# Altium schematic sheet Z24 - Arduino Mega Adapter.SchDoc("Z24 - Arduino Mega Adapter")
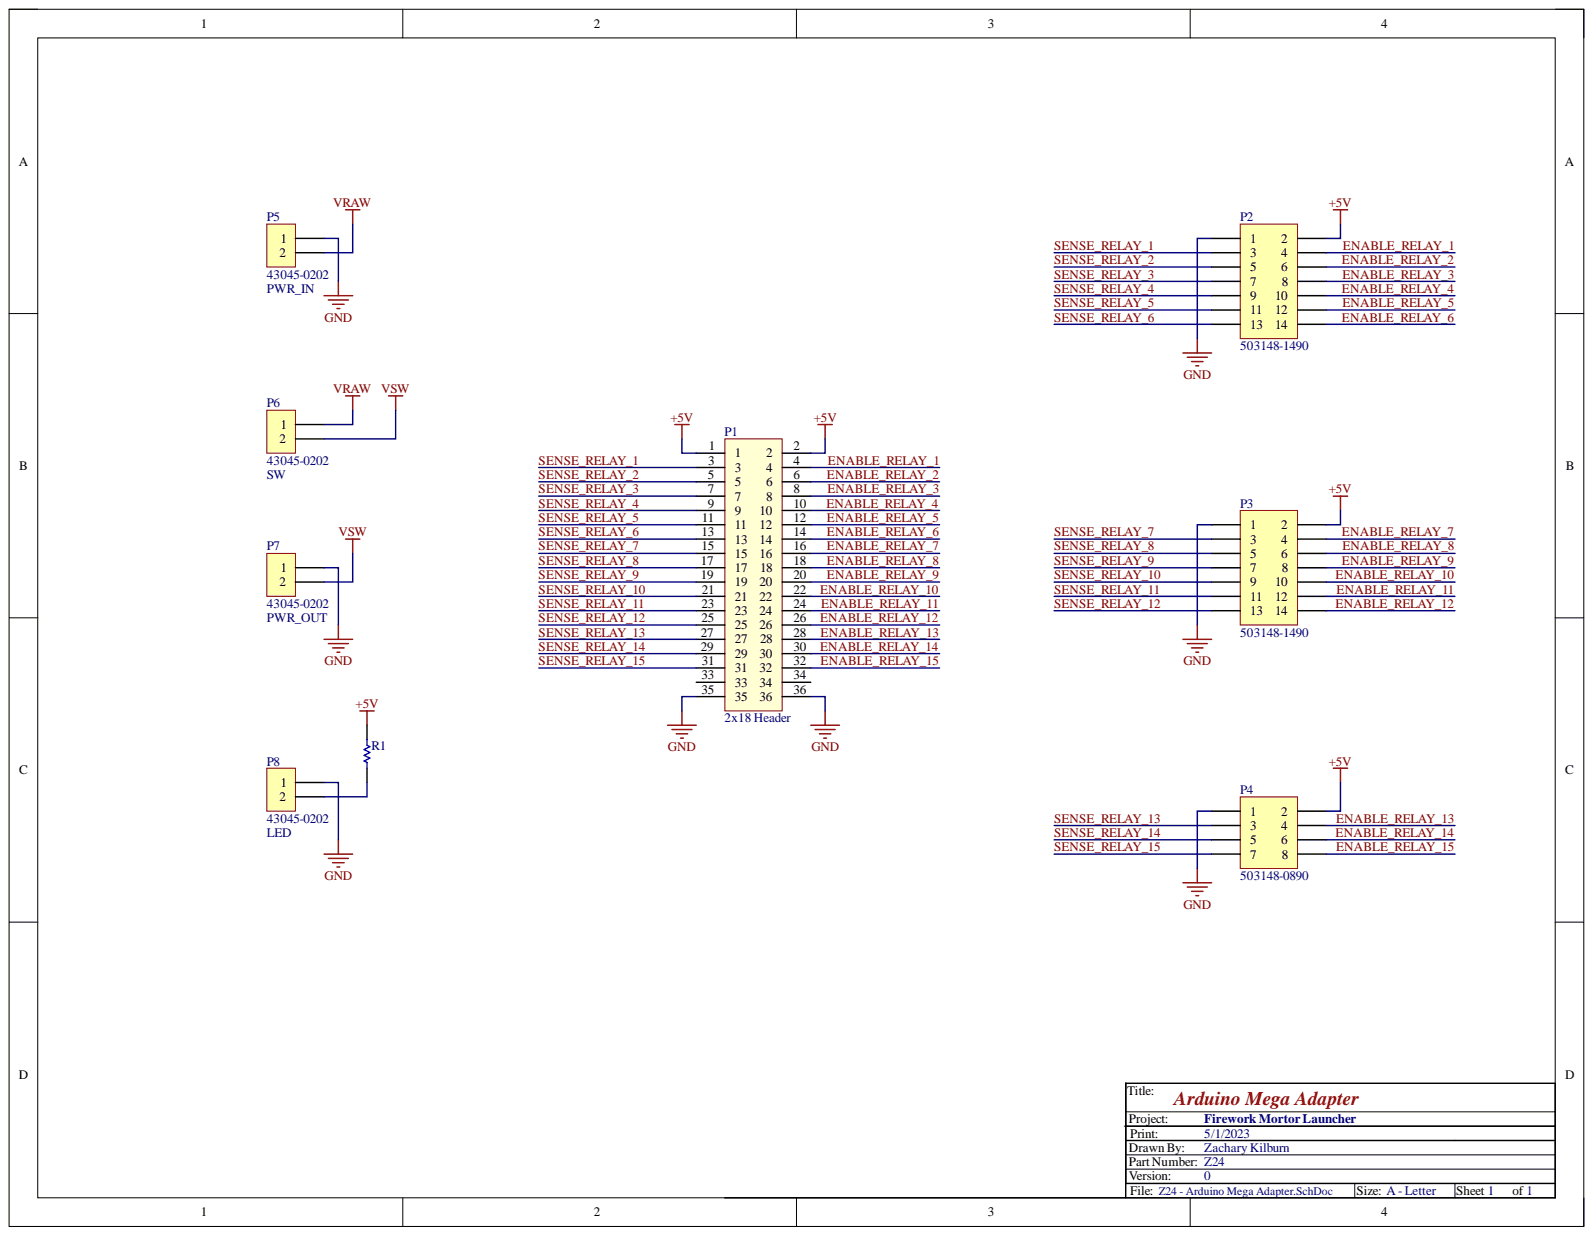
[diagram p0: Z24 - Arduino Mega Adapter.SchDoc]

## Parts
P1  2x18 Header  [2x18 PIN 0.1"]
P2  503148-1490  [PCC-MOLX-503148-1490-R]
P3  503148-1490  [PCC-MOLX-503148-1490-R]
P4  503148-0890  [PCC-MOLX-503148-0890-R]
P5  43045-0202  Name: PWR_IN  [PCC-MOLX-43045-0202-R]
P6  43045-0202  Name: SW  [PCC-MOLX-43045-0202-R]
P7  43045-0202  Name: PWR_OUT  [PCC-MOLX-43045-0202-R]
P8  43045-0202  Name: LED  [PCC-MOLX-43045-0202-R]
R1  ERA3AEB104V  [RESC1608X55X30ML20T20]  (value not drawn)

## Nets
+5V: P1.1 P1.2 P2.2 P3.2 P4.2 R1.2
GND: P1.35 P1.36 P2.1 P3.1 P4.1 P5.1 P7.1 P8.1
VRAW: P5.2 P6.1
VSW: P6.2 P7.2
ENABLE_RELAY_1: P1.4 P2.4
ENABLE_RELAY_2: P1.6 P2.6
ENABLE_RELAY_3: P1.8 P2.8
ENABLE_RELAY_4: P1.10 P2.10
ENABLE_RELAY_5: P1.12 P2.12
ENABLE_RELAY_6: P1.14 P2.14
ENABLE_RELAY_7: P1.16 P3.4
ENABLE_RELAY_8: P1.18 P3.6
ENABLE_RELAY_9: P1.20 P3.8
ENABLE_RELAY_10: P1.22 P3.10
ENABLE_RELAY_11: P1.24 P3.12
ENABLE_RELAY_12: P1.26 P3.14
ENABLE_RELAY_13: P1.28 P4.4
ENABLE_RELAY_14: P1.30 P4.6
ENABLE_RELAY_15: P1.32 P4.8
SENSE_RELAY_1: P1.3 P2.3
SENSE_RELAY_2: P1.5 P2.5
SENSE_RELAY_3: P1.7 P2.7
SENSE_RELAY_4: P1.9 P2.9
SENSE_RELAY_5: P1.11 P2.11
SENSE_RELAY_6: P1.13 P2.13
SENSE_RELAY_7: P1.15 P3.3
SENSE_RELAY_8: P1.17 P3.5
SENSE_RELAY_9: P1.19 P3.7
SENSE_RELAY_10: P1.21 P3.9
SENSE_RELAY_11: P1.23 P3.11
SENSE_RELAY_12: P1.25 P3.13
SENSE_RELAY_13: P1.27 P4.3
SENSE_RELAY_14: P1.29 P4.5
SENSE_RELAY_15: P1.31 P4.7
NetP8_2: P8.2 R1.1

Not connected: P1 33 34

## Library data of the parts
P1 = 2x18 Header: 0.1" Header, 2x18, 36 Positions; Component Kind: Standard; Library Name: Headers.SchLib
P2 P3 = CMP-000071-0: Molex CLIK-Mate Series Connector, Dual-Row, 14-Position, Right Angle, 1.5mm Pitch; Current: 2A; MatingStyle: Plug; PackingType: Reel; Positions: 14; Rows: 2; Voltage: 100V; Component Kind: Standard; ComponentLink1Description: Manufacturer URL; ComponentLink1URL: http://www.molex.com; ComponentLink2Description: Product URL; ComponentLink2URL: http://www.molex.com/molex/products/datasheet.jsp?part=active/5031481490_PCB_RECEPTACLES.xml; ComponentLink3Description: Datasheet; ComponentLink3URL: http://www.molex.com/pdm_docs/ps/PS-503148-001-001.pdf; ComponentLink4Description: Package Specification; ComponentLink4URL: http://www.molex.com/pdm_docs/sd/5031481490_sd.pdf; HelpURL: http://www.molex.com/pdm_docs/sd/5031481490_sd.pdf; Library Name: Connectors.SchLib; Manufacturer: Molex; MountingTechnology: Surface Mount; OperatingTemperature: -40C to +105C; Orientation: Right Angle; PackageName: CLIK-Mate; PartNumber: 503148-1490; Pitch: 1.5mm; RoHS: Yes; StorageTemperature: +5C to +35C
P4 = CMP-000068-0: Molex CLIK-Mate Series Connector, Dual-Row, 8-Position, Right Angle, 1.5mm Pitch; Current: 2A; MatingStyle: Plug; PackingType: Reel; Positions: 8; Rows: 2; Voltage: 100V; Component Kind: Standard; ComponentLink1Description: Manufacturer URL; ComponentLink1URL: http://www.molex.com; ComponentLink2Description: Product URL; ComponentLink2URL: http://www.molex.com/molex/products/datasheet.jsp?part=active/5031480890_PCB_RECEPTACLES.xml; ComponentLink3Description: Datasheet; ComponentLink3URL: http://www.molex.com/pdm_docs/ps/PS-503148-001-001.pdf; ComponentLink4Description: Package Specification; ComponentLink4URL: http://www.molex.com/pdm_docs/sd/5031480890_sd.pdf; HelpURL: http://www.molex.com/pdm_docs/sd/5031480890_sd.pdf; Library Name: Connectors.SchLib; Manufacturer: Molex; MountingTechnology: Surface Mount; OperatingTemperature: -40C to +105C; Orientation: Right Angle; PackageName: CLIK-Mate; PartNumber: 503148-0890; Pitch: 1.5mm; RoHS: Yes; StorageTemperature: +5C to +35C
P5-P8 = CMP-000202-0: Molex Micro-Fit 3.0 Connector, Dual-Row, 2-Position, Right-Angle, 3mm Pitch; Current: 5A; MatingStyle: Plug; Positions: 2; Voltage: 250V; Component Kind: Standard; ComponentLink1Description: Manufacturer URL; ComponentLink1URL: http://www.molex.com; ComponentLink2Description: Product URL; ComponentLink2URL: http://www.molex.com/molex/products/datasheet.jsp?part=active/0430450202_PCB_HEADERS.xml; ComponentLink3Description: Datasheet; ComponentLink3URL: http://www.molex.com/pdm_docs/ps/PS-43045.pdf; ComponentLink4Description: Package Specification; ComponentLink4URL: http://www.molex.com/pdm_docs/sd/430450202_sd.pdf; HelpURL: http://www.molex.com/pdm_docs/ps/PS-43045.pdf; Library Name: Molex-Micro-Fit.SchLib; Manufacturer: Molex; MountingTechnology: Through Hole; OperatingTemperature: -40C to +105C; Orientation: Right Angle; PackageName: Micro-Fit 3.0; PartNumber: 43045-0202; Pitch: 3mm; RoHS: Yes; Rows: 2; StorageTemperature: -40C to +105C
R1 = CMP-2000-00875-1: Manufacturer: Panasonic; Component Kind: Standard; Library Name: Altium Content Vault; Part Number: ERA3AEB104V
